# Altium schematic sheet ControlPlateSTM32.SchDoc
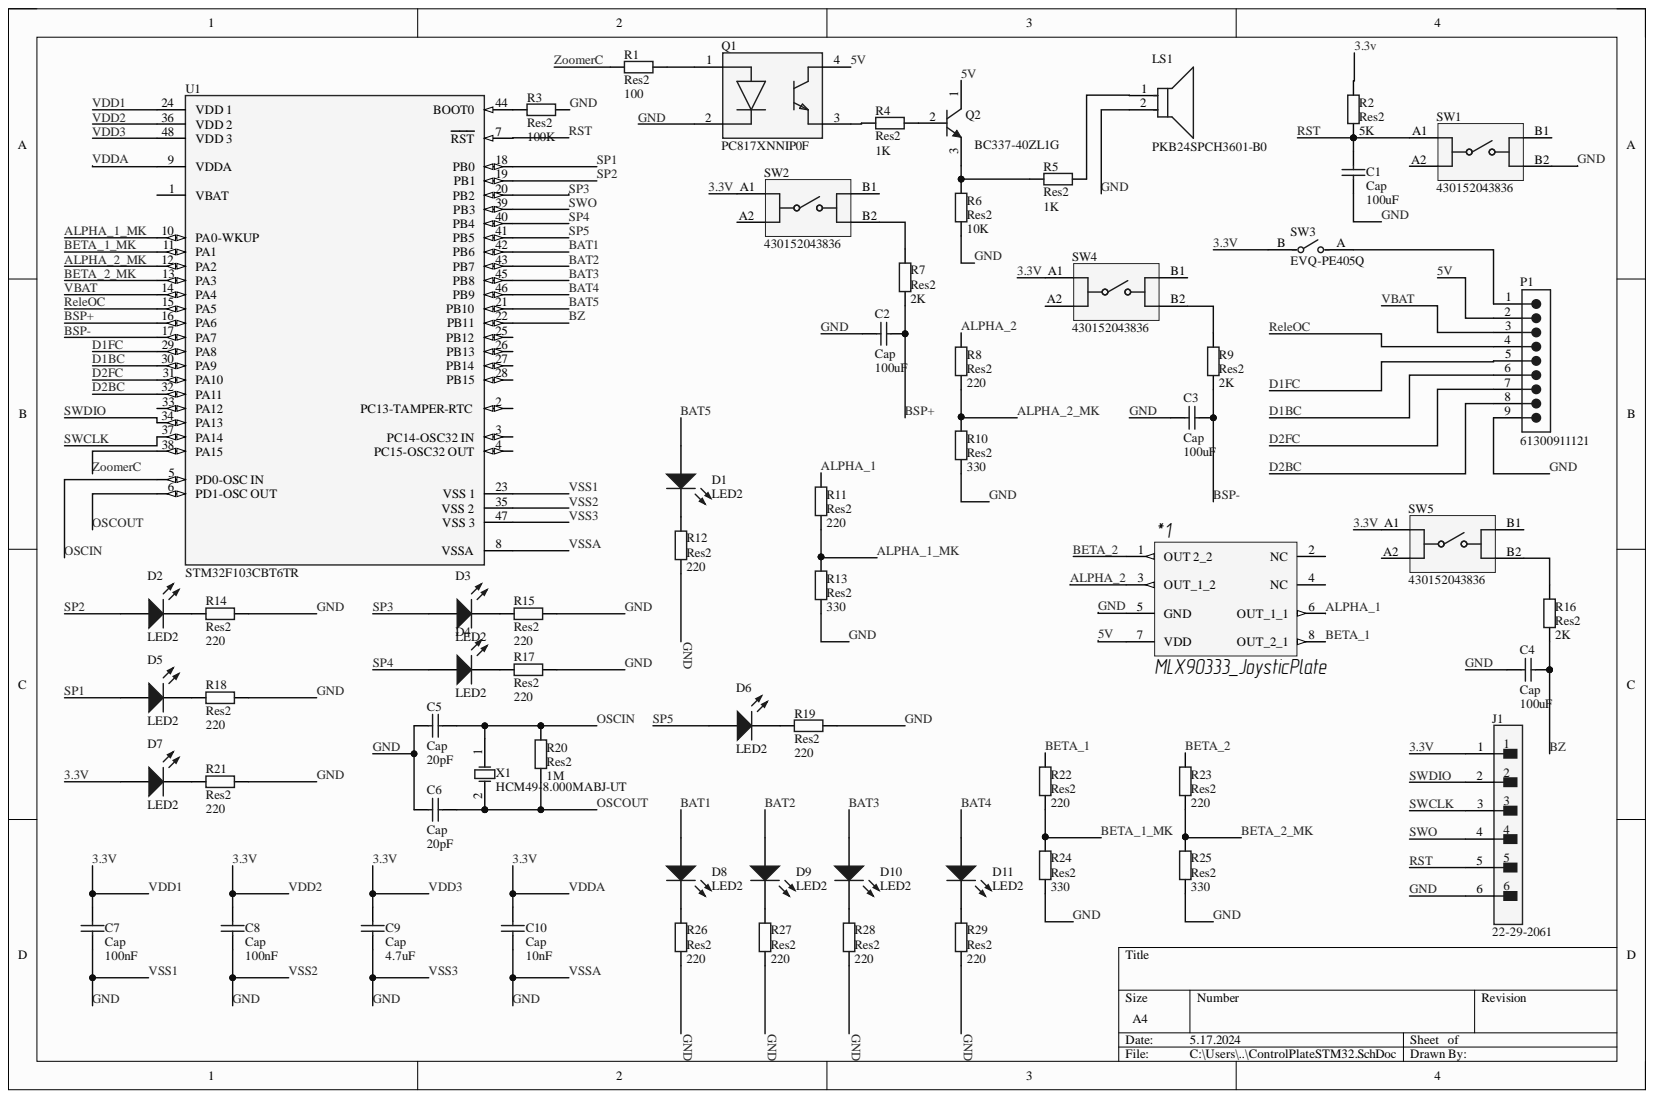
[diagram p0: ControlPlateSTM32.SchDoc]

## Parts
*1  MLX90333_JoysticPlate  [MLX90333_Joy]
C1  Cap  value 100uF  [RAD-0.3]
C2  Cap  value 100uF  [RAD-0.3]
C3  Cap  value 100uF  [RAD-0.3]
C4  Cap  value 100uF  [RAD-0.3]
C5  Cap  value 20pF  [RAD-0.3]
C6  Cap  value 20pF  [RAD-0.3]
C7  Cap  value 100nF  [RAD-0.3]
C8  Cap  value 100nF  [RAD-0.3]
C9  Cap  value 4.7uF  [RAD-0.3]
C10  Cap  value 10nF  [RAD-0.3]
D1  LED2  [3.2X1.6X1.1]
D2  LED2  [3.2X1.6X1.1]
D3  LED2  [3.2X1.6X1.1]
D4  LED2  [3.2X1.6X1.1]
D5  LED2  [3.2X1.6X1.1]
D6  LED2  [3.2X1.6X1.1]
D7  LED2  [3.2X1.6X1.1]
D8  LED2  [3.2X1.6X1.1]
D9  LED2  [3.2X1.6X1.1]
D10  LED2  [3.2X1.6X1.1]
D11  LED2  [3.2X1.6X1.1]
J1  22-29-2061  [FP-22-29-2061-MFG]
LS1  PKB24SPCH3601-B0  [FP-PKB24SPCH3601-B0-MFG]
P1  61300911121  [61300911121]
Q1  PC817XNNIP0F  [FP-PC817XNNIP0F-MFG]
Q2  BC337-40ZL1G  [ONSC-TO-92-3-29-11_AM_BL]
R1  Res2  value 100  [AXIAL-0.4]
R2  Res2  value 5K  [AXIAL-0.4]
R3  Res2  value 100K  [AXIAL-0.4]
R4  Res2  value 1K  [AXIAL-0.4]
R5  Res2  value 1K  [AXIAL-0.4]
R6  Res2  value 10K  [AXIAL-0.4]
R7  Res2  value 2K  [AXIAL-0.4]
R8  Res2  value 220  [AXIAL-0.4]
R9  Res2  value 2K  [AXIAL-0.4]
R10  Res2  value 330  [AXIAL-0.4]
R11  Res2  value 220  [AXIAL-0.4]
R12  Res2  value 220  [AXIAL-0.4]
R13  Res2  value 330  [AXIAL-0.4]
R14  Res2  value 220  [AXIAL-0.4]
R15  Res2  [AXIAL-0.4]
R16  Res2  value 2K  [AXIAL-0.4]
R17  Res2  value 220  [AXIAL-0.4]
R18  Res2  value 220  [AXIAL-0.4]
R19  Res2  value 220  [AXIAL-0.4]
R20  Res2  value 1M  [AXIAL-0.4]
R21  Res2  value 220  [AXIAL-0.4]
R22  Res2  value 220  [AXIAL-0.4]
R23  Res2  value 220  [AXIAL-0.4]
R24  Res2  value 330  [AXIAL-0.4]
R25  Res2  value 330  [AXIAL-0.4]
R26  Res2  value 220  [AXIAL-0.4]
R27  Res2  value 220  [AXIAL-0.4]
R28  Res2  value 220  [AXIAL-0.4]
R29  Res2  value 220  [AXIAL-0.4]
SW1  430152043836  [WS-TASV_L6W6H4.3_4301x20438x6]
SW2  430152043836  [WS-TASV_L6W6H4.3_4301x20438x6]
SW3  EVQ-PE405Q  [FP-EVQ-PE405Q-MFG]
SW4  430152043836  [WS-TASV_L6W6H4.3_4301x20438x6]
SW5  430152043836  [WS-TASV_L6W6H4.3_4301x20438x6]
U1  STM32F103CBT6TR  [FP-LQFP48-5B-MFG]
X1  HCM49-8.000MABJ-UT  [CFM-HCM49-2_V]

## Nets
3.3v: C7.2 C8.2 C9.2 C10.2 D7.1 J1.1 R2.1 SW2.A1 SW3.B SW4.A1 SW5.A1 U1.9(VDDA) U1.24(VDD_1) U1.36(VDD_2) U1.48(VDD_3)
5V: *1.7(VDD) P1.2 Q1.4 Q2.1
ALPHA_1: *1.6(OUT_1_1) R11.2
ALPHA_1_MK: R11.1 R13.2 U1.10(PA0-WKUP)
ALPHA_2: *1.3(OUT_1_2) R8.2
ALPHA_2_MK: R8.1 R10.2 U1.12(PA2)
BAT1: D8.1 U1.42(PB6)
BAT2: D9.1 U1.43(PB7)
BAT3: D10.1 U1.45(PB8)
BAT4: D11.1 U1.46(PB9)
BAT5: D1.1 U1.21(PB10)
BETA_1: *1.8(OUT_2_1) R22.2
BETA_1_MK: R22.1 R24.2 U1.11(PA1)
BETA_2: *1.1(OUT_2_2) R23.2
BETA_2_MK: R23.1 R25.2 U1.13(PA3)
BSP+: C2.1 R7.2 U1.16(PA6)
BSP-: C3.1 R9.2 U1.17(PA7)
BZ: C4.1 R16.2 U1.22(PB11)
D1BC: P1.6 U1.30(PA9)
D1FC: P1.5 U1.29(PA8)
D2BC: P1.8 U1.32(PA11)
D2FC: P1.7 U1.31(PA10)
GND: *1.5(GND) C1.1 C2.2 C3.2 C4.2 C5.2 C6.2 C7.1 C8.1 C9.1 C10.1 J1.6 LS1.2 P1.9 Q1.2 R3.1 R6.2 R10.1 R12.1 R13.1 R14.1 R15.1 R17.1 R18.1 R19.1 R21.1 R24.1 R25.1 R26.1 R27.1 R28.1 R29.1 SW1.B2 U1.8(VSSA) U1.23(VSS_1) U1.35(VSS_2) U1.47(VSS_3)
OSCIN: C5.1 R20.1 U1.5(PD0-OSC_IN) X1.1
OSCOUT: C6.1 R20.2 U1.6(PD1-OSC_OUT) X1.2
ReleOC: P1.4 U1.15(PA5)
RST: C1.2 J1.5 R2.2 SW1.A1 U1.7(RST)
SP1: D5.1 U1.18(PB0)
SP2: D2.1 U1.19(PB1)
SP3: D3.1 U1.20(PB2)
SP4: D4.1 U1.40(PB4)
SP5: D6.1 U1.41(PB5)
SWCLK: J1.3 U1.37(PA14)
SWDIO: J1.2 U1.34(PA13)
SWO: J1.4 U1.39(PB3)
VBAT: P1.3 U1.14(PA4)
ZoomerC: R1.1 U1.38(PA15)
NetD1_2: D1.2 R12.2
NetD2_2: D2.2 R14.2
NetD3_2: D3.2 R15.2
NetD4_2: D4.2 R17.2
NetD5_2: D5.2 R18.2
NetD6_2: D6.2 R19.2
NetD7_2: D7.2 R21.2
NetD8_2: D8.2 R26.2
NetD9_2: D9.2 R27.2
NetD10_2: D10.2 R28.2
NetD11_2: D11.2 R29.2
NetLS1_1: LS1.1 R5.1
NetP1_1: P1.1 SW3.A
NetQ1_1: Q1.1 R1.2
NetQ1_3: Q1.3 R4.1
NetQ2_2: Q2.2 R4.2
NetQ2_3: Q2.3 R5.2 R6.1
NetR3_2: R3.2 U1.44(BOOT0)
NetR7_1: R7.1 SW2.B2
NetR9_1: R9.1 SW4.B2
NetR16_1: R16.1 SW5.B2

Not connected: *1 2(NC) 4(NC); SW1 A2 B1; SW2 A2 B1; SW4 A2 B1; SW5 A2 B1; U1 1(VBAT) 2(PC13-TAMPER-RTC) 3(PC14-OSC32_IN) 4(PC15-OSC32_OUT) 25(PB12) 26(PB13) 27(PB14) 28(PB15) 33(PA12)

## Library data of the parts
C1-C10 = Cap: Capacitor; Component Kind: Standard; LatestRevisionDate: 17-Jul-2002; LatestRevisionNote: Re-released for DXP Platform.; Library Name: Miscellaneous Devices.IntLib; PackageDescription: Radial Cap, Thru-Hole; 2 Leads; 0.3 in Pin Spacing; PackageReference: RAD-0.3; Published: 8-Jun-2000; Signal Integrity: Cap; Simulation: CAP; Publisher: Altium Limited
D1-D11 = LED2: Typical RED, GREEN, YELLOW, AMBER GaAs LED; Component Kind: Standard; LatestRevisionDate: 17-Jul-2002; LatestRevisionNote: Re-released for DXP Platform.; Library Name: Miscellaneous Devices.IntLib; PackageDescription: SM LED; 2 Flat Leads; PackageReference: 3.2X1.6X1.1; PackageVersion: Feb-2002; Published: 8-Jun-2000; Simulation: LED2; Publisher: Altium Limited
J1 = CMP-04776-000348-1: CONN HEADER VERT 6POS 2.54MM; Contact Resistance: 10mR; Current Rating: 4A; Gender: Male; Insulation Resistance: 50GR; Number of Contacts: 6; Number of Lines: 1; Voltage Rating: 250V; Color: Natural; Component Kind: Standard; Contact Gender: Male; Contact Material: Brass; Contact Mating Length: 0.295inch; Contact Plating: Gold; Contact Type: Male Pin; Depth: 5.8mm; Fastening Type: Friction; Flammability Rating: UL94 V-0; Height: 11.7mm; Housing Color: White; Housing Material: Nylon; Lead Free: Lead Free; Length: 15.24mm; Library Name: Altium Content Vault; Max Current Rating: 4A; Max Operating Temperature: 75°C; Min Operating Temperature: 0°C; Mount: Through Hole; Number of Poles: 6; Number of Positions: 6; Number of Rows: 1; Orientation: Straight; Package Quantity: 1000; Packaging: Bulk; Pitch: 2.54mm; Radiation Hardening: No; RoHS Compliant: Yes; Series: KK® 6410; Termination: Solder; Voltage Rating (DC): 250V
LS1 = CMP-06203-000001-2: AUDIO PIEZO TRANSDUCER 3-15V TH; Frequency: 3.6kHz; Max Frequency: 4.1kHz; Max Supply Current: 16mA; Max Supply Voltage: 15V; Min Supply Voltage: 3V; Nominal Supply Voltage (DC): 12V; Washable: No; Component Kind: Standard; Diameter: 24.3mm; Height: 9.7mm; Lead Free: Lead Free; Length: 24.3mm; Library Name: Altium Content Vault; Max Operating Temperature: 70°C; Max Supply Voltage (DC): 15V; Min Operating Temperature: -20°C; Min Supply Voltage (DC): 3V; Mount: Flush; Nominal Supply Current: 16mA; Operating Supply Current: 16mA; Package Quantity: 650; Packaging: Bulk; Radiation Hardening: No; REACH SVHC: No SVHC; Self Resonant Frequency: 3.6kHz; Termination: PC Pins; Voltage Rating: 12V; Voltage Rating (DC): 15V
P1 = CMP-1502-01080-2: NexarPartId: 32855536; Connector Type: Male Pin; Contact Resistance: 20mΩ; Current Rating: 3A; Gender: Male; Number of Contacts: 9; Operating Supply Voltage: 250V; Pitch: 2.54mm; Color: Black; Component Kind: Standard; Contact Material: Copper; Contact Mating Length: 5.9944mm; Contact Plating: Gold; Lead Pitch: 2.54mm; Library Name: Altium Content Vault; Mount: Through Hole; Number of Pins: 9; Number of Positions: 9; Number of Rows: 1; Orientation: Vertical; Packaging: Bulk; REACH SVHC: Unknown; RoHS: Compliant; Termination: Solder
Q1 = CMP-65434-000005-1: OPTOISOLATOR 5KV TRANS 4SMD; Collector Emitter Saturation Voltage: 200mV; Collector Emitter Voltage (VCEO): 80V; Fall Time: 18000ns; Forward Current: 50mA; Forward Voltage: 1.4V; Input Type: DC; Max Power Dissipation: 200mW; Number of Channels: 1; Output Current per Channel: 30mA; Reverse Breakdown Voltage: 6V; Case/Package: SMD/SMT; Collector-emitter Voltage-Max: 200mV; Component Kind: Standard; Contact Plating: Copper; Input Current: 50mA; Isolation Voltage: 5kV; Isolation Voltage-Max: 5kV; Lead Free: Lead Free; Library Name: Altium Content Vault; Max Collector Current: 50mA; Max Input Current: 50mA; Max Operating Temperature: 100°C; Max Output Voltage: 80V; Min Operating Temperature: -30°C; Mount: Surface Mount; Number of Elements: 1; Number of Pins: 4; Output Voltage: 80V; Package Quantity: 2000; Packaging: Tape and Reel; Power Dissipation: 200mW; Radiation Hardening: No; Rise Time: 18000ns; RoHS Compliant: Yes
Q2 = CMP-1048-01583-1: Amplifier Transistor, NPN Silicon, 3-Pin TO-92, Pb-Free, Ammo Box; Manufacturer: On Semiconductor; IC Continuous (A): 0.8; Component Kind: Standard; ComponentLink1Description: Manufacturer URL; ComponentLink1URL: http://www.onsemi.com/; ComponentLink2Description: Datasheet; ComponentLink2URL: http://www.onsemi.com/pub_link/Collateral/BC337-D.PDF; ComponentLink3Description: Package Specification; ComponentLink3URL: http://www.onsemi.com/pub_link/Collateral/MAC97-D.PDF; DatasheetVersion: Rev. 8; Library Name: Altium Content Vault; Mounting Technology: Through Hole; PackageDescription: 3-Pin Transistor Outline, Body 4.825 x 3.685 mm, Pitch 1.27 mm; PackageReference: TO-92-3-29-11_AM_BL; PackageVersion: Rev. AM; Packing: Ammo Box; PartNumber: BC337-40ZL1G; Polarity: NPN; RoHS: TRUE
R1 R2 R4-R14 R16-R29 = Res2: Resistor; Component Kind: Standard; LatestRevisionDate: 17-Jul-2002; LatestRevisionNote: Re-released for DXP Platform.; Library Name: Miscellaneous Devices.IntLib; PackageDescription: Axial Device, Thru-Hole; 2 Leads; 0.4 in Pin Spacing; PackageReference: AXIAL-0.4; Published: 8-Jun-2000; Signal Integrity: Res; Simulation: RESISTOR; Publisher: Altium Limited
R3 = Res2: Resistor; Value: 100K; Component Kind: Standard; LatestRevisionDate: 17-Jul-2002; LatestRevisionNote: Re-released for DXP Platform.; Library Name: Miscellaneous Devices.IntLib; PackageDescription: Axial Device, Thru-Hole; 2 Leads; 0.4 in Pin Spacing; PackageReference: AXIAL-0.4; Published: 8-Jun-2000; Signal Integrity: Res; Simulation: RESISTOR; Publisher: Altium Limited
R15 = Res2: Resistor; Value: 220; Component Kind: Standard; LatestRevisionDate: 17-Jul-2002; LatestRevisionNote: Re-released for DXP Platform.; Library Name: Miscellaneous Devices.IntLib; PackageDescription: Axial Device, Thru-Hole; 2 Leads; 0.4 in Pin Spacing; PackageReference: AXIAL-0.4; Published: 8-Jun-2000; Signal Integrity: Res; Simulation: RESISTOR; Publisher: Altium Limited
SW1 SW2 SW4 SW5 = CMP-1452-00002-2: NexarPartId: 22861785; Actuator Length: 900µm; Actuator Material: Liquid Crystal Polymer, Polymer; Contact Current Rating: 50mA; Illumination Type: Illuminated, Non-Illuminated; Insulation Resistance: 100MΩ; Mechanical Life: 200kcycles; Switch Function: Off-Mom; Switch Travel: 250µm; Throw Configuration: SPST; Voltage Rating: 12V; Color: Orange; Component Kind: Standard; Contact Material: Stainless Steel, Steel; Contact Plating: Silver; Current Rating: 50mA; Diameter: 3.5mm; Height: 4.3mm; Length: 6.2mm; Library Name: Altium Content Vault; Max Operating Temperature: 85°C; Min Operating Temperature: -40°C; Mount: Surface Mount; Packaging: Tape and Reel; REACH SVHC: No SVHC; RoHS: Compliant; Termination: Gull Wing; Width: 6.2mm
SW3 = CMP-05618-000107-1: SWITCH TACTILE SPST-NO 0.05A 12V; Actuator Color: Grey; Actuator Length: 1.5mm; Actuator Type: Standard; Contact Current Rating: 50mA; Contact Resistance: 100mR; Electrical Life: 50000Cycles; Illumination Type: Non-Illuminated; Operating Force: 1N; Switch Function: Off-Mom; Switch Travel: 0.25mm; Throw Configuration: SPST; Component Kind: Standard; Contact Plating: Silver; Current Rating: 50mA; Depth: 7.9mm; Height: 5mm; Lead Free: Lead Free; Length: 6mm; Library Name: Altium Content Vault; Max Current Rating: 50mA; Max Operating Temperature: 85°C; Max Voltage Rating (DC): 12V; Mechanical Life: 50000Cycles; Min Operating Temperature: -30°C; Mount: Through Hole; Orientation: Straight; Package Quantity: 1000; Packaging: Bulk; REACH SVHC: No SVHC; Series: EVQPE; Size: 3.5mm; Termination: PC Pin; Voltage Rating (DC): 12V; Width: 3.5mm
U1 = CMP-0237-00247-4: NexarPartId: 12442975; Core Architecture: ARM; Data Bus Width: 32b; Flash Memory Size: 128kB; Frequency: 72MHz; Interface: CAN, I2C, IrDA, LIN, SPI, USART, USB; Max Junction Temperature (Tj): 105°C; Max Power Dissipation: 363mW; Max Supply Voltage: 3.6V; Memory Type: FLASH; Min Supply Voltage: 2V; Nominal Supply Current: 1.7µA; Number of A/D Converters: 2; Number of ADC Channels: 10; Number of I/Os: 37; Number of PWM Channels: 4; Number of UART Channels: 3; Number of USB Channels: 1; Oscillator Type: Internal; Peripherals: DMA, I2C, POR, PWM, Temp Sensor, WDT; RAM Size: 20kB; Watchdog Timer: Yes; Ambient Temperature Range High: 105°C; Case/Package: LQFP; Component Kind: Standard; Height: 1.6mm; Lead Free: Lead Free; Length: 7mm; Library Name: Altium Content Vault; Lifecycle Status: Production; Max Frequency: 72MHz; Max Operating Temperature: 85°C; Memory Size: 128kB; Min Operating Temperature: -40°C; Number of Channels: 10; Number of I2C Channels: 2; Number of Pins: 48; Number of Programmable I/O: 37; Number of SPI Channels: 2; Number of Timers/Counters: 4; Number of USART Channels: 3; Packaging: Tape & Reel (TR); Radiation Hardening: No; RoHS: Compliant; Schedule B: 8542310000
X1 = CMP-1215-00001-1: At-Cut Crystal Unit, 3.5 to 30 MHz, -40 to 85 degC, 2-Pin 11.4Ã—4.7Ã—4.3 mm SMD, RoHS, Reel; Manufacturer: Citizen Finetech Miyota; C0: 7 pF Max.; DL: 100 uW; IR: 500 MOhm Min.; R1: 50 to 200 Ohm; Component Kind: Standard; ComponentLink1Description: Manufacturer URL; ComponentLink1URL: http://cfm.citizen.co.jp/english/index.html; ComponentLink2Description: Datasheet; ComponentLink2URL: http://cfm.citizen.co.jp/english/product/pdf/HCM49.pdf; ComponentLink3Description: Package Specification; ComponentLink3URL: http://cfm.citizen.co.jp/english/product/pdf/HCM49.pdf; DatasheetVersion: Jan-12; f0: 3.5 to 30 MHz; Library Name: Altium Content Vault; Mounting Technology: Surface Mount; Operating Temperature: -40 to 85 degC; PackageDescription: 2-Pin Surface Mount, Body 11.4 x 4.7 mm, Pitch 8.5 mm; Packing: Reel; PartNumber: HCM49-8.000MABJ-UT; RoHS: TRUE
*1 = MLX90333_JoysticPlate: Component Kind: Standard; Library Name: Joystiks.SchLib
